# Altium schematic sheet Overview.SchDoc
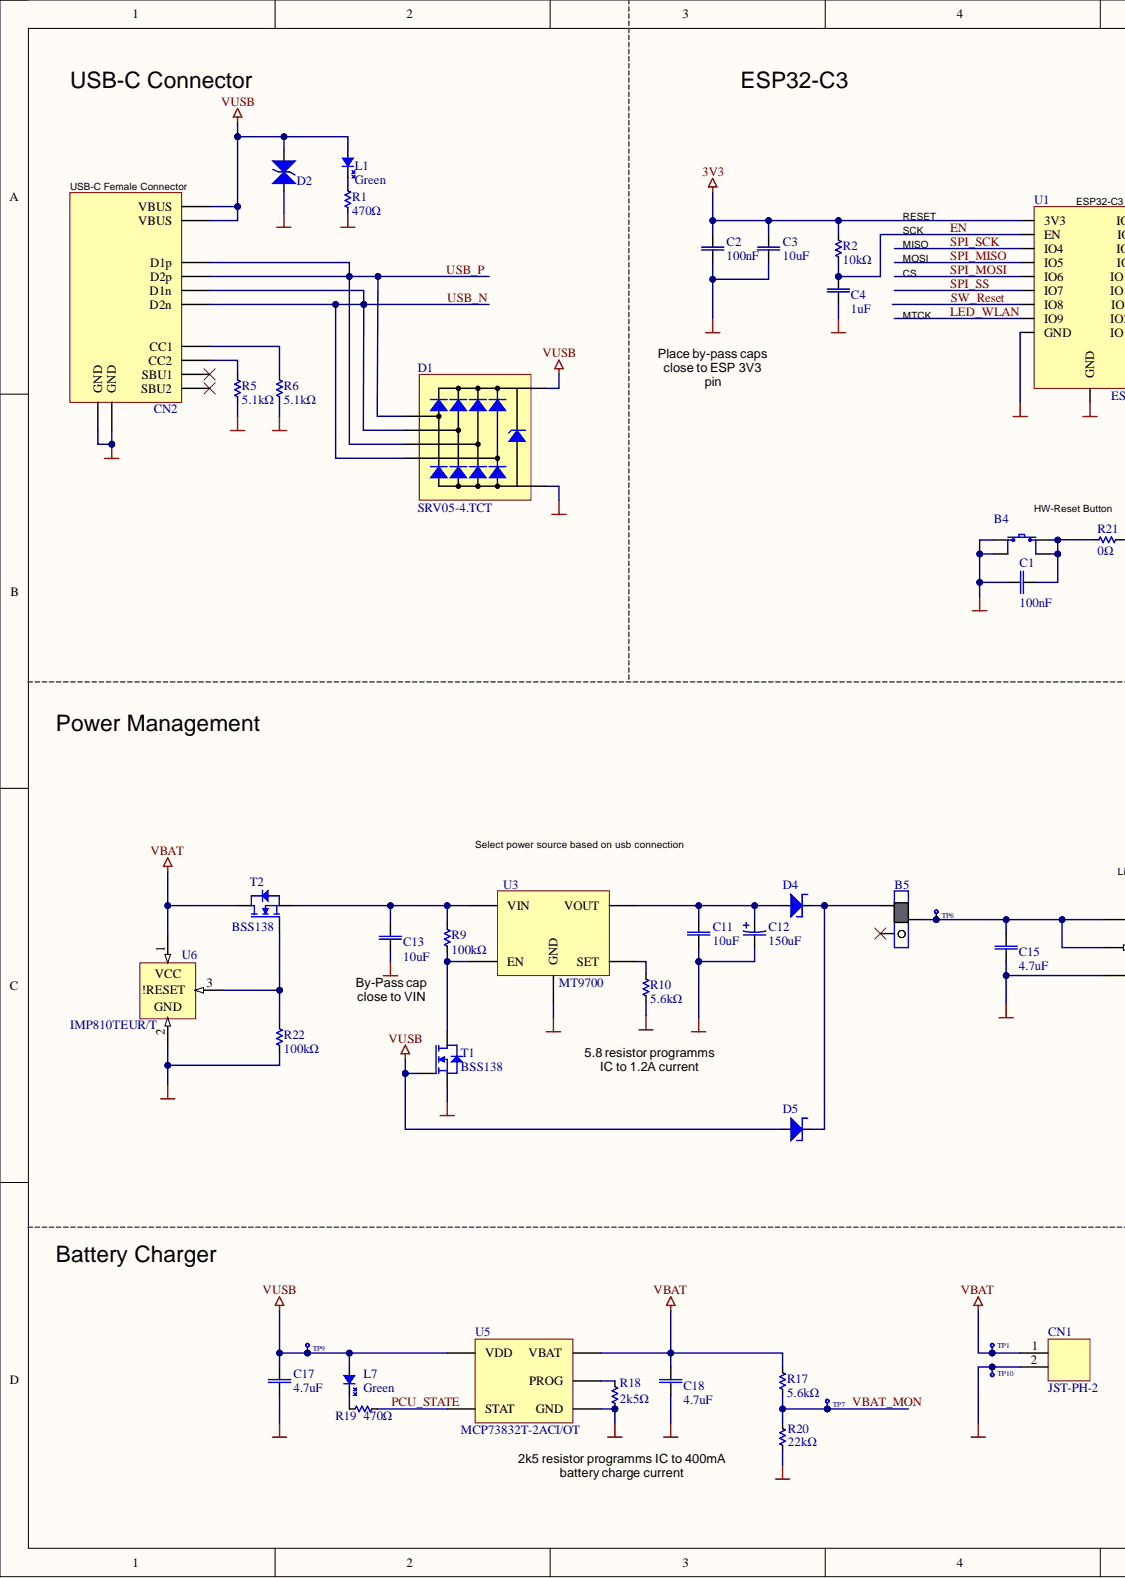
[diagram p0: Overview.SchDoc, left]
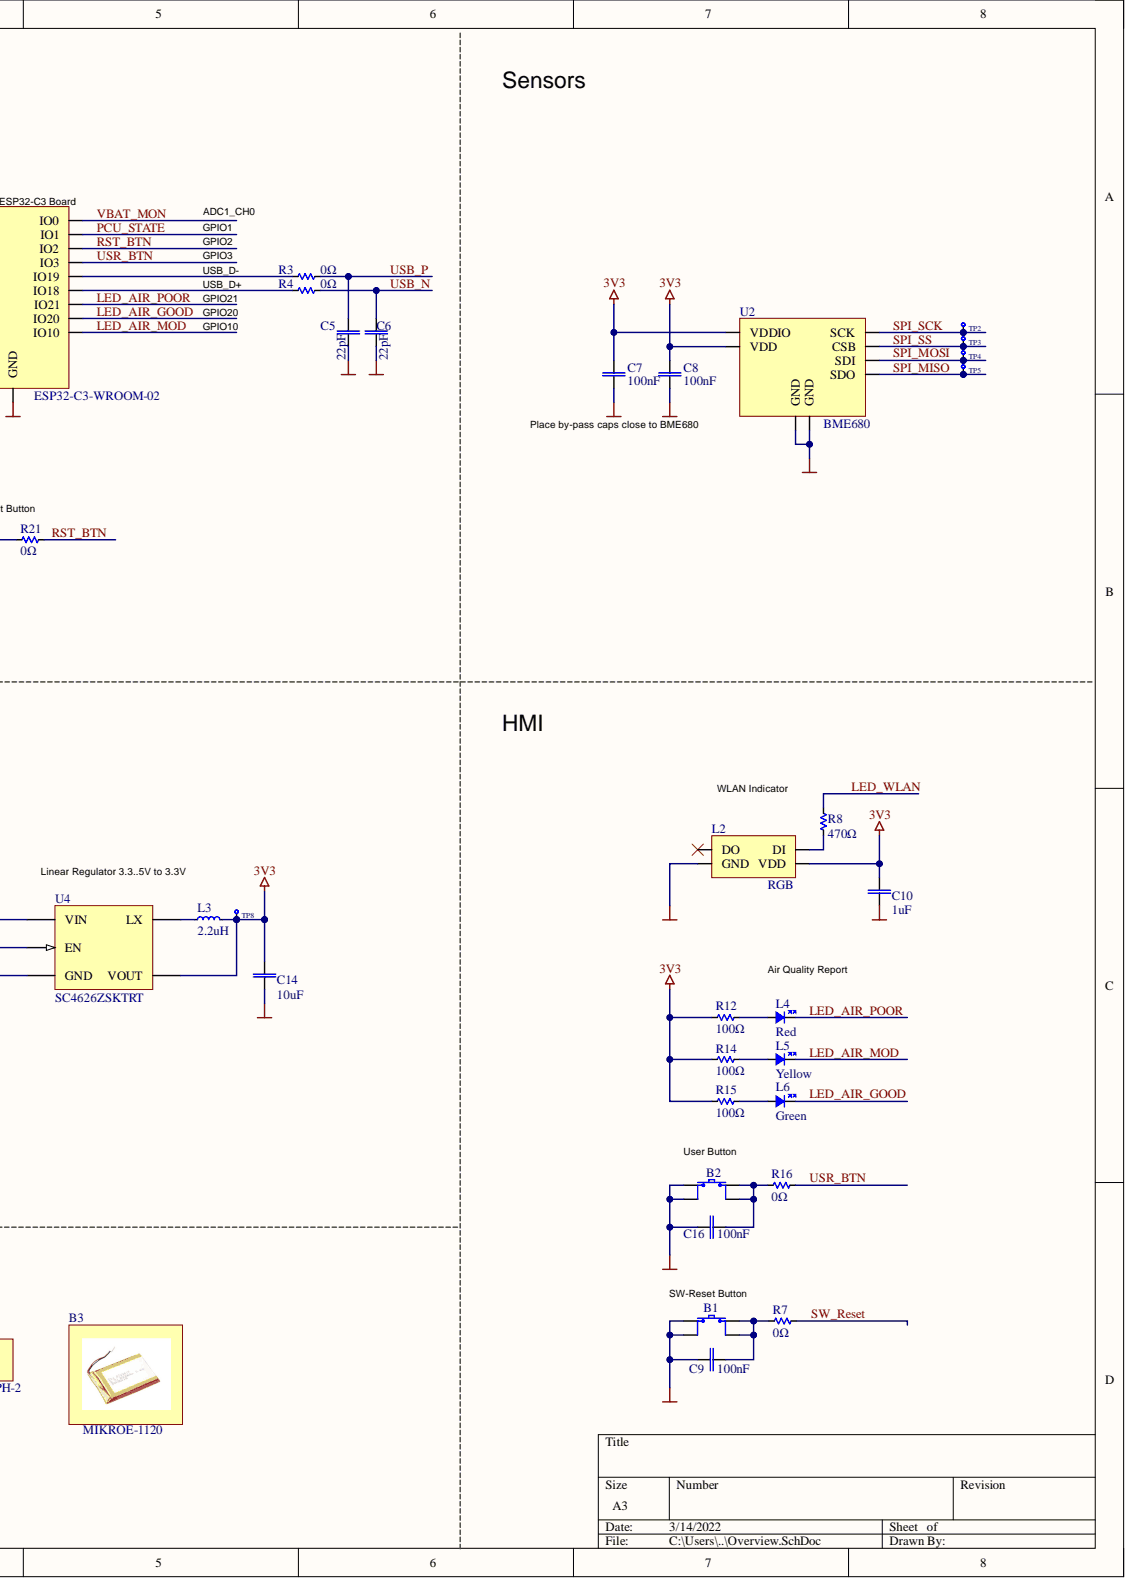
[diagram p1: Overview.SchDoc, right]

## Parts
B1  EVQP7C01P  [K2-1102ST-A4SC-04-Footprint-1]  (value not drawn)
B2  ADTSM644KV  [ADTSM644KV-Footprint-1]  (value not drawn)
B3  MIKROE-1120  [MIKROE-1120-Footprint-1]
B4  KMR221NGLFS  [KSC441G70SHLFS-Footprint-1]  (value not drawn)
B5  SSAL220100  [SSAL220100-Footprint-1]  (value not drawn)
C1  Capacitance: 100nF  [SAMSUNG_CAPC0603]  (hidden comment: CC0603KRX7R9BB104)
C2  Capacitance: 100nF  [SAMSUNG_CAPC0603]  (hidden comment: CC0603KRX7R9BB104)
C3  Capacitance: 10uF  [SAMSUNG_CAPC1206]  (hidden comment: CL31A106KBHNNNE)
C4  Capacitance: 1uF  [SAMSUNG_CAPC0603]  (hidden comment: CL10A105KB8NNNC)
C5  Capacitance: 22pF  [SAMSUNG_CAPC0603]  (hidden comment: CL10C220JB8NNNC)
C6  Capacitance: 22pF  [SAMSUNG_CAPC0603]  (hidden comment: CL10C220JB8NNNC)
C7  Capacitance: 100nF  [SAMSUNG_CAPC0603]  (hidden comment: CC0603KRX7R9BB104)
C8  Capacitance: 100nF  [SAMSUNG_CAPC0603]  (hidden comment: CC0603KRX7R9BB104)
C9  Capacitance: 100nF  [SAMSUNG_CAPC0603]  (hidden comment: CC0603KRX7R9BB104)
C10  Capacitance: 1uF  [SAMSUNG_CAPC0603]  (hidden comment: CL10A105KB8NNNC)
C11  Capacitance: 10uF  [SAMSUNG_CAPC1206]  (hidden comment: CL31A106KBHNNNE)
C12  Capacitance: 150uF  [NICHICON_CAPAE6.3_5.8]  (hidden comment: UUD0J151MCL1GS)
C13  Capacitance: 10uF  [SAMSUNG_CAPC1206]  (hidden comment: CL31A106KBHNNNE)
C14  Capacitance: 10uF  [SAMSUNG_CAPC1206]  (hidden comment: CL31A106KBHNNNE)
C15  Capacitance: 4.7uF  [SAMSUNG_CAPC0603]  (hidden comment: CL10A475KO8NNNC)
C16  Capacitance: 100nF  [SAMSUNG_CAPC0603]  (hidden comment: CC0603KRX7R9BB104)
C17  Capacitance: 4.7uF  [SAMSUNG_CAPC0603]  (hidden comment: CL10A475KO8NNNC)
C18  Capacitance: 4.7uF  [SAMSUNG_CAPC0603]  (hidden comment: CL10A475KO8NNNC)
CN1  JST-PH-2  [1769-Footprint-1]
CN2  216990-0001  [216990-0001-Footprint-1]  (value not drawn)
D1  SRV05-4.TCT  [SEMTECH_SOT23-6L]
D2  LESD5D5.0CT1G  [LRC_SOD-523]  (value not drawn)
D4  B320A-13-F  [DIODES_SMA]  (value not drawn)
D5  B320A-13-F  [DIODES_SMA]  (value not drawn)
L1  Color: Green  [EVERLIGHT_LED0603]  (hidden comment: 19-217/GHC-YR1S2/3T)
L2  Color: RGB  [4492-Footprint-1]  (hidden comment: NeoPixel 1515)
L3  Inductance: 2.2uH  [SUNLORD_INDP3030X15N]  (hidden comment: SWPA3015S2R2MT)
L4  KT-0603R  [EVERLIGHT_LED0603]  (value not drawn)
L5  Color: Yellow  [EVERLIGHT_LED0603]  (hidden comment: 19-213/Y2C-CQ2R2L/3T)
L6  Color: Green  [EVERLIGHT_LED0603]  (hidden comment: 19-217/GHC-YR1S2/3T)
L7  Color: Green  [EVERLIGHT_LED0603]  (hidden comment: 19-217/GHC-YR1S2/3T)
R1  Resistance: 470Ω  [UNIROYAL_RES0603]  (hidden comment: 0603WAF4700T5E)
R2  Resistance: 10kΩ  [UNIROYAL_RES0603]  (hidden comment: 0603WAF1002T5E)
R3  Resistance: 0Ω  [UNYROYAL_RES1206]  (hidden comment: 1206W4F0000T5E)
R4  Resistance: 0Ω  [UNYROYAL_RES1206]  (hidden comment: 1206W4F0000T5E)
R5  Resistance: 5.1kΩ  [UNIROYAL_RES0603]  (hidden comment: 0603WAF5101T5E)
R6  Resistance: 5.1kΩ  [UNIROYAL_RES0603]  (hidden comment: 0603WAF5101T5E)
R7  Resistance: 0Ω  [UNYROYAL_RES1206]  (hidden comment: 1206W4F0000T5E)
R8  Resistance: 470Ω  [UNIROYAL_RES0603]  (hidden comment: 0603WAF4700T5E)
R9  Resistance: 100kΩ  [UNIROYAL_RES0402]  (hidden comment: 0402WGF1003TCE)
R10  Resistance: 5.6kΩ  [UNIROYAL_RES0603]  (hidden comment: 0603WAF5601T5E)
R12  Resistance: 100Ω  [UNIROYAL_RES0603]  (hidden comment: 0603WAF1000T5E)
R14  Resistance: 100Ω  [UNIROYAL_RES0603]  (hidden comment: 0603WAF1000T5E)
R15  Resistance: 100Ω  [UNIROYAL_RES0603]  (hidden comment: 0603WAF1000T5E)
R16  Resistance: 0Ω  [UNYROYAL_RES1206]  (hidden comment: 1206W4F0000T5E)
R17  Resistance: 5.6kΩ  [UNIROYAL_RES0603]  (hidden comment: 0603WAF5601T5E)
R18  Resistance: 2k5Ω  [VIKING_0603RES]  (hidden comment: AR03BTC2501)
R19  Resistance: 470Ω  [UNIROYAL_RES0603]  (hidden comment: 0603WAF4700T5E)
R20  Resistance: 22kΩ  [UNIROYAL_RES0603]  (hidden comment: 0603WAF2202T5E)
R21  Resistance: 0Ω  [UNYROYAL_RES1206]  (hidden comment: 1206W4F0000T5E)
R22  Resistance: 100kΩ  [UNIROYAL_RES0402]  (hidden comment: 0402WGF1003TCE)
T1  BSS138  [ONSEMI_SOT23-3]
T2  BSS138  [ONSEMI_SOT23-3]
TP1  Testpoint  [Testpoint-Footprint-1]  (value not drawn)  (no BOM)
TP2  Testpoint  [Testpoint-Footprint-1]  (value not drawn)  (no BOM)
TP3  Testpoint  [Testpoint-Footprint-1]  (value not drawn)  (no BOM)
TP4  Testpoint  [Testpoint-Footprint-1]  (value not drawn)  (no BOM)
TP5  Testpoint  [Testpoint-Footprint-1]  (value not drawn)  (no BOM)
TP6  Testpoint  [Testpoint-Footprint-1]  (value not drawn)  (no BOM)
TP7  Testpoint  [Testpoint-Footprint-1]  (value not drawn)  (no BOM)
TP8  Testpoint  [Testpoint-Footprint-1]  (value not drawn)  (no BOM)
TP9  Testpoint  [Testpoint-Footprint-1]  (value not drawn)  (no BOM)
TP10  Testpoint  [Testpoint-Footprint-1]  (value not drawn)  (no BOM)
U1  ESP32-C3-WROOM-02  [ESP32-C3-WROOM-02-Footprint-1]
U2  BME680  [BOSCH_8-VFLGA]
U3  MT9700  [AEROSEMI_SOT23-5]
U4  SC4626ZSKTRT  Min Output Voltage: 3.3V  [SOT95P240X145-5N]
U5  MCP73832T-2ACI/OT  [MICOCHIP_SOT23-5]
U6  IMP810TEUR/T  [SOT95P240X110-3N]

## Nets
3V3: C2.2 C3.2 C7.2 C8.2 C10.2 C14.2 L2.4(VDD) L3.2 R2.2 R12.1 R14.1 R15.1 TP8.1 U1.1(3V3) U2.6(VDDIO) U2.8(VDD) U4.4(VOUT)
GND: B1.1 B1.3 B2.1 B2.3 B4.1 B4.3 C1.1 C2.1 C3.1 C4.1 C5.1 C6.1 C7.1 C8.1 C9.1 C10.1 C11.2 C12.2 C13.2 C14.1 C15.1 C16.1 C17.1 C18.1 CN1.2 CN2.1(GND) CN2.12(GND) D1.2 D2.2 L2.2(GND) R1.2 R5.1 R6.1 R10.1 R18.1 R20.1 R22.1 T1.2 TP10.1 U1.9(GND) U1.19(GND) U2.1(GND) U2.7(GND) U3.2(GND) U4.2(GND) U5.2(GND) U6.2
VBAT: C18.2 CN1.1 R17.2 T2.3 TP1.1 U5.3(VBAT) U6.1
VUSB: C17.2 CN2.2(VBUS) CN2.11(VBUS) D1.5 D2.1 D5.2 L1.2 L7.2 T1.1 TP9.1 U5.4(VDD)
EN: C4.2 R2.1 U1.2(EN)
LED_AIR_GOOD: L6.1 U1.11(IO20)
LED_AIR_MOD: L5.1 U1.10(IO10)
LED_AIR_POOR: L4.1 U1.12(IO21)
LED_WLAN: R8.2 U1.8(IO9)
PCU_STATE: R19.1 U1.17(IO1) U5.1(STAT)
RST_BTN: R21.2 U1.16(IO2)
SPI_MISO: TP5.1 U1.4(IO5) U2.5(SDO)
SPI_MOSI: TP4.1 U1.5(IO6) U2.3(SDI)
SPI_SCK: TP2.1 U1.3(IO4) U2.4(SCK)
SPI_SS: TP3.1 U1.6(IO7) U2.2(CSB)
SW_Reset: R7.2 U1.7(IO8)
USB_N: C6.2 CN2.5(D2n) CN2.7(D1n) D1.3 D1.6 R4.2
USB_P: C5.2 CN2.6(D1p) CN2.8(D2p) D1.1 D1.4 R3.2
USR_BTN: R16.2 U1.15(IO3)
VBAT_MON: R17.1 R20.2 TP7.1 U1.18(IO0)
NetB1_2: B1.2 B1.4 C9.2 R7.1
NetB2_2: B2.2 B2.4 C16.2 R16.1
NetB4_2: B4.2 B4.4 C1.2 R21.1
NetB5_1: B5.1 D4.1 D5.1
NetB5_3: B5.3 C15.2 TP6.1 U4.1(VIN) U4.3(EN)
NetC11_1: C11.1 C12.1 D4.2 U3.1(VOUT)
NetC13_1: C13.1 R9.2 T2.2 U3.5(VIN)
NetCN2_4: CN2.4(CC1) R6.2
NetCN2_10: CN2.10(CC2) R5.2
NetL1_1: L1.1 R1.1
NetL2_3: L2.3(DI) R8.1
NetL3_1: L3.1 U4.5(LX)
NetL4_2: L4.2 R12.2
NetL5_2: L5.2 R14.2
NetL6_2: L6.2 R15.2
NetL7_1: L7.1 R19.2
NetR3_1: R3.1 U1.14(IO19)
NetR4_1: R4.1 U1.13(IO18)
NetR9_1: R9.1 T1.3 U3.4(EN)
NetR10_2: R10.2 U3.3(SET)
NetR18_2: R18.2 U5.5(PROG)
NetR22_2: R22.2 T2.1 U6.3

Not connected: B5 2; CN2 3(SBU2) 9(SBU1); L2 1(DO)

## Library data of the parts
B1 = CMP-011-00001-3: SWITCH TACTILE SPST-NO 0.05A 12V; Contact Current Rating: 50mA; Mechanical Life: 100000Cycles; Operating Force: 2.2N; Power Rating: 1W; Throw Configuration: SPST; Voltage Rating (DC): 32V; Component Kind: Standard; Height: 7.3; JLCPCB: C388883; Max Current Rating: 50mA; Max Operating Temperature: 70°C; Max Voltage Rating (DC): 12V; Min Operating Temperature: -20°C; Mount: Surface Mount; Orientation: Straight; REACH SVHC: No SVHC; Library Name: Pedrett
B2 = CMP-011-00003-2: Switch Tactile N.O. SPST Square Button Gull Wing 0.05A 12VDC 0.98N SMD Bulk; Actuator Color: Black; Actuator Length: 3.8mm; Actuator Material: Nylon,Polyamide; Contact Resistance: 100mR; Current Rating: 50mA; Electrical Life: 200000Cycles; Illumination Type: Non-Illuminated; Max Voltage Rating (DC): 12V; Operating Force: 100gf; Switch Function: Off-Mom; Switch Travel: 0.25mm; Case/Package: SMD/SMT; Color: Black; Component Kind: Standard; Contact Material: Bronze,Silver; Contact Plating: Silver; Max Operating Temperature: 70°C; Min Operating Temperature: -25°C; Mount: Surface Mount; Orientation: Straight; Package Quantity: 77; Packaging: Bulk; RoHS Compliant: Yes; Series: ADTS; Termination: Gull Wing; Throw Configuration: SPST; Voltage Rating (DC): 12V; Library Name: Pedrett
B3 = CMP-00044-6: 3.7VWire Lead Term Rechargeable Lithium Battery Pack For Power Supply, 2000mAh; Number of Cells: 1; Operating Supply Voltage: 3.7V; Battery Chemistry: LITHIUM; Capacity: 2000mAh; Chemistry/Technology: Lithium; Component Kind: Standard; Height: 8.5mm; Lead Free: Lead Free; Length: 62.0mm; Output Voltage: 3.7V; Package Quantity: 1; Packaging: Bulk; Rechargeability: Rechargeable; Termination: Wire Leads; Voltage Rating: 3.7V; Weight: 78.5g; Width: 38.5mm; Library Name: Pedrett
B4 = CMP-011-00002-2: Switch Tactile N.O. SPST Button Gull Wing 0.05A 32VDC 1VA 200000Cycles 2N SMD Automotive T/R; Contact Current Rating: 50mA; Max Voltage Rating (DC): 32V; Power Rating: 1W; Ratings: IP67,Waterproof,Dust Tight; Switch Function: Off-Mom; Component Kind: Standard; Height: 5.2mm; JLCPCB: C221747; Max Current Rating: 50mA; Max Operating Temperature: 85°C; Mechanical Life: 200000Cycles; Min Operating Temperature: -40°C; Mount: Surface Mount; Operating Force: 2N; Orientation: Straight; REACH SVHC: No SVHC; Series: KMR2; Throw Configuration: SPST; Voltage Rating (DC): 32V; Library Name: Pedrett
B5 = CMP-00045-5: Switch Slide SPDT Side Slide 0.01A 5VDC 100000Cycles Gull Wing SMD T/R; Contact Current Rating: 10mA; Illumination Type: Non-Illuminated; Max Voltage Rating (DC): 5V; Throw Configuration: SPDT,SP; Case/Package: SMD/SMT; Component Kind: Standard; Max Operating Temperature: 60°C; Min Operating Temperature: -10°C; Mount: Surface Mount; Packaging: Tape and Reel; Radiation Hardening: No; RoHS Compliant: Yes; Series: SSAL; Termination: Gull Wing; Voltage Rating (DC): 5V; Library Name: Pedrett
C1 C2 C7-C9 C16 = CMP-001-00000-5: Cap Ceramic 0.1uF 50V X7R 10% SMD 0603 125C Paper T/R; Case/Package: 0603; Component Kind: Standard; Depth: 0.8mm; Dielectric: X7R; JLCPCB: C14663; Length: 1.6mm; Max Operating Temperature: 125°C; Min Operating Temperature: -55°C; Mount: Surface Mount; RoHS Compliant: Yes; Tolerance: 10%; Voltage: 50V; Library Name: Pedrett
C3 C11 C13 C14 = CMP-001-00003-2: SAMSUNG ELECTRO-MECHANICS - CL31A106KBHNNNE - SMD Multilayer Ceramic Capacitor, 10 µF, 50 V, 1206 [3216 Metric], ± 10%, X5R, CL Series; Voltage: 50V; Case/Package: 1206; Component Kind: Standard; Depth: 1.6mm; Dielectric: X5R; JLCPCB: C13585; Length: 3.2mm; Max Operating Temperature: 85°C; Min Operating Temperature: -55°C; Mount: Surface Mount; RoHS Compliant: Yes; Tolerance: 10%; Library Name: Pedrett
C4 C10 = CMP-001-00004-1: Cap Ceramic 1uF 50V X5R 10% SMD 0603 85C Cardboard T/R; Case/Package: 0603; Component Kind: Standard; Dielectric: X5R; Height: 0.8mm; JLCPCB: C15849; Length: 1.6mm; Material: Ceramic; Max Operating Temperature: 85°C; Min Operating Temperature: -55°C; Mount: Surface Mount; RoHS Compliant: Yes; Tolerance: 10%; Voltage: 50V; Library Name: Pedrett
C5 C6 = CMP-001-00012-1: Ceramic capacitor SMD-0603 22pF /50V NP0# J +-5%, Samsung, RoHS; Dielectric: C0G; Tolerance: 5%; Voltage: 50V; Case/Package: 0603; Component Kind: Standard; Height: 0.8mm; JLCPCB: C1653; Length: 0.063inch; Material: Ceramic; Max Operating Temperature: 125°C; Min Operating Temperature: -55°C; Mount: Surface Mount; RoHS Compliant: Yes; Library Name: Pedrett
C12 = CMP-001-00010-1: Cap Aluminum Lytic 150uF 6.3V 20% (6.3 X 5.8mm) SMD 230mA 2000h 105C Automotive T/R; Dissipation Factor: 0.26%; Impedance: 440mR; Leakage Current: 3uA; Life (Hours): 2000Hour; Case/Package: Radial; Component Kind: Standard; Construction: Cylindrical; Diameter: 6.3mm; Height: 5.8mm; Height - Seated (Max): 0.228inch; JLCPCB: C445282; Lead Free: Lead Free; Length: 5.8mm; Max Operating Temperature: 105°C; Min Operating Temperature: -55°C; Number of Pins: 2; Package Quantity: 1; Packaging: Tape and Reel; Radiation Hardening: No; Ripple Current: 230mA; RoHS Compliant: Yes; Series: UD; Termination: SMD/SMT; Tolerance: 20%; Voltage Rating: 6.3V; Voltage Rating (DC): 6.3V; Library Name: Pedrett
C15 C17 C18 = CMP-001-00006-1: Ceramic capacitor SMD 0603 4.7 µF 16 V 10 %; Dielectric: X5R; Tolerance: 10%; Voltage: 16V; Case/Package: 0603; Component Kind: Standard; Height: 0.8mm; JLCPCB: C19666; Length: 1.6mm; Material: Ceramic; Max Operating Temperature: 85°C; Min Operating Temperature: -55°C; Mount: Surface Mount; RoHS Compliant: Yes; Library Name: Pedrett
CN1 = CMP-002-00010-2: CML 1769 LMP T-1 3/4 INC Midget Screw .2A 2.5V Part of the VCC Family of Products; Connector Type: Male Pin; Contact Resistance: 10mR; Current Rating: 2A; Max Voltage Rating (AC): 100V; Number of Contacts: 2; Pitch: 2.5mm; Color: Natural; Component Kind: Standard; Contact Material: Brass; Contact Plating: Tin; Contact Type: Male Pin; Lead Free: Lead Free; Lead Pitch: 2.5mm; Length: 7.4mm; Max Current Rating: 2A; Max Operating Temperature: 85°C; Max Voltage Rating (DC): 100V; Min Operating Temperature: -25°C; Mount: SMD; Number of Positions: 2; Number of Rows: 1; Orientation: Right Arrow; REACH SVHC: No SVHC; Library Name: Pedrett
CN2 = CMP-002-00009-4: USB Type - Connector, Mid-mount, SMT, CH-0.52, 16pos; Current Max (Other): 1.25A; Current Max (VBus): 30V; Durability: 10000 Cycles; Component Kind: Standard; Max Operating Temperature: 85°C; Min Operating Temperature: -40°C; Pin: 16; Voltage AC: 30V; Library Name: Pedrett
D1 = CMP-00030-3: SEMTECH - SRV05-4.TCT - ESD Protection Device, TVS, 17.5 V, SOT-23, 6 Pins, 5 V, 300 W; Breakdown Voltage: 6V; Capacitance: 5pF; Clamping Voltage: 17.5V; Current: 5A; ESD Protection: Yes; Max Power Dissipation: 300W; Max Reverse Leakage Current: 5uA; Number of Bidirectional Channels: 4; Operating Supply Voltage: 5V; Peak Pulse Current: 12A; Polarity: Unidirectional; Case/Package: SOT-23; Component Kind: Standard; JLCPCB: C13612; Lead Free: Lead Free; Max Breakdown Voltage: 6V; Max Operating Temperature: 125°C; Min Breakdown Voltage: 6V; Min Operating Temperature: -55°C; Mount: Surface Mount; Peak Pulse Power: 300W; REACH SVHC: No SVHC; Reverse Breakdown Voltage: 6V; Reverse Standoff Voltage: 5V; RoHS Compliant: Yes; Series: RailClamp®, SR; Library Name: Pedrett
D2 = CMP-00043-2: 5V 5.6V Bidirectional 18.6V 9.4A (8/20us) SOD-523 ESD Protection Devices ROHS; Clamping Voltage: 11.6; Peak Pulse Power: 150W; Breakdown Voltage: 5.6V; Case/Package: SOD-523; Component Kind: Standard; JLCPCB: C383211; RoHS Compliant: Yes; Voltage: 5V; Working Peak Reverse Voltage: 5V; Library Name: Pedrett
D4 D5 = CMP-004-00001-1: Rectifier Diode Schottky 20V 3A 2-Pin SMA T/R / DIODE SCHOTTKY 20V 3A SMA; Capacitance: 250pF; Element Configuration: Single; Forward Voltage: 500mV; Max Forward Surge Current (Ifsm): 80A; Max Reverse Current: 500uA; Polarity: Standard; Average Rectified Current: 3A; Case/Package: SMA; Component Kind: Standard; Current Rating: 3A; Forward Current: 3A; Height: 2.3mm; JLCPCB: C110484; Lead Free: Lead Free; Length: 4.6mm; Max Operating Temperature: 150°C; Max Repetitive Reverse Voltage (Vrrm): 20V; Max Reverse Voltage (DC): 20V; Min Operating Temperature: -55°C; Mount: Surface Mount; Number of Pins: 2; Output Current: 3A; Package Quantity: 5000; Packaging: Tape and Reel; Peak Non-Repetitive Surge Current: 80A; Radiation Hardening: No; REACH SVHC: No SVHC; RoHS Compliant: Yes; Series: -; Termination: SMD/SMT; Voltage Rating (DC): 20V; Weight: 0.002258oz; Width: 2.92mm; Library Name: Pedrett
L1 L6 L7 = CMP-00009-9: LED Uni-Color Green 518nm 2-Pin Chip LED T/R; Dominant Wavelength: 528nm; Forward Current: 20mA; Forward Voltage: 3.3V; Lens Color: Colorless; Lens Style: Clear,Diffused,Colorless,Rectangular; Lens Transparency: Clear; Luminous Intensity: 199mcd; Viewing Angle: 120°; Case/Package: 0603; Component Kind: Standard; Height: 0.45mm; JLCPCB: C72043; Lead Free: Lead Free; Length: 1.6mm; Mount: Surface Mount; Radiation Hardening: No; RoHS Compliant: Yes; Library Name: Pedrett
L2 = CMP-001-0010-1: NeoPixel Addressable 1515 LEDs (1.5mm x 1.5mm) - 10 pack; Dominant Wavelength: 465nm; Forward Voltage: 3.7 to 5.5V; Lens Color: Colorless; Lens Transparency: Clear; Luminous Intensity: 30mcd; Test Current: 5mA; Viewing Angle: 120°; Component Kind: Standard; Height: 2.1mm; Length: 1.5mm; Mount: Surface Mount; Packaging: Tape and Reel; RoHS Compliant: Yes; Width: 1.5mm; Library Name: Pedrett
L3 = CMP-005-00001-1: 2.2uH ±10% 2.45A 60mΩ SMD,3.0x3.0x1.5mm Power Inductors RoHS; Component Kind: Standard; DC Resistance: 60mΩ; JLCPCB: C43389; Rated Current: 2.45A; RoHS: Yes; Tolerance: ±10%; Library Name: Pedrett
L4 = CMP-001-0005-1: LED Uni-Color Red 630nm 2-Pin Chip LED T/R; Forward Current: 20mA; Forward Voltage: 1.9; Lens Color: Colorless; Lens Style: Clear,Diffused,Colorless,Rectangular; Lens Transparency: Clear; Luminous Intensity: 199mcd; Viewing Angle: 70°; Case/Package: 0603; Color: Red; Component Kind: Standard; Dominant Wavelength: 630nm; Height: 0.45mm; JLCPCB: C2286; Lead Free: Lead Free; Length: 1.6mm; Mount: Surface Mount; Radiation Hardening: No; RoHS Compliant: Yes; Library Name: Pedrett
L5 = CMP-001-0000-9: SMD LED yellow 1.7. . .2.3 V 0603, 19-213/Y2C-CQ2R2L/3T, Everlight Electronics; Forward Current: 20mA; Forward Voltage: 2.3V; Lens Color: Clear; Lens Style: Diffused,Colorless,Rectangular; Luminous Intensity: 180mcd; Power Rating: 60mW; Viewing Angle: 120°; Case/Package: 0603; Component Kind: Standard; Illumination Color: Yellow; JLCPCB: C72038; Max Operating Temperature: 85°C; Min Operating Temperature: -40°C; Library Name: Pedrett
R1 R8 R19 = CMP-009-00024-4: 470Ω ±1% 0.1W ±100ppm/℃ 0603 Chip Resistor - Surface Mount RoHS; Montage: SMD; Voltage: 50 V; Case/Package: 0603; Component Kind: Standard; JLCPCB: C23179; Power: 0.1W; RoHS: Yes; RoHS Compliant: Yes; Temperature Coefficient: ±100ppm/℃; Tolerance: ±1%; Library Name: Pedrett
R2 = CMP-009-00003-3: 10kΩ ±1% 0.1W ±100ppm/℃ 0603 Chip Resistor - Surface Mount RoHS; Montage: SMD; Voltage: 50 V; Case/Package: 0603; Component Kind: Standard; JLCPCB: C25804; Power: 0.1W; RoHS: Yes; RoHS Compliant: Yes; Temperature Coefficient: ±100ppm/℃; Tolerance: ±1%; Library Name: Pedrett
R3 R4 R7 R16 R21 = CMP-009-00020-3: CHIP RESISTOR - SURFACE MOUNT 0OHMS ±1% 1/4W 1206 ROHS; Montage: SMD; Power: 0.25W; Temperature Coefficient: 200ppm/°C; Voltage: 50 V; Case/Package: 1206; Component Kind: Standard; JLCPCB: C17888; RoHS: Yes; RoHS Compliant: Yes; Tolerance: ±1%; Library Name: Pedrett
R5 R6 = CMP-009-00026-3: 5.1kΩ ±1% 0.1W ±100ppm/℃ 0603 Chip Resistor - Surface Mount RoHS; Montage: SMD; Power: 0.125W; Voltage: 50 V; Case/Package: 0603; Component Kind: Standard; JLCPCB: C23186; RoHS: Yes; RoHS Compliant: Yes; Temperature Coefficient: ±100ppm/℃; Tolerance: ±1%; Library Name: Pedrett
R9 R22 = CMP-009-00005-4: 100kΩ ±1% 0.0625W ±100ppm/℃ 0402 Chip Resistor - Surface Mount RoHS; Montage: SMD; Voltage: 50 V; Case/Package: 0402; Component Kind: Standard; JLCPCB: C25741; Power: 0.0625W; RoHS: Yes; RoHS Compliant: Yes; Temperature Coefficient: ±100ppm/℃; Tolerance: ±1%; Library Name: Pedrett
R10 R17 = CMP-009-00010-2: 5.6kΩ ±1% 0.1W ±100ppm/℃ 0603 Chip Resistor - Surface Mount RoHS; Montage: SMD; Power: 0.125W; Voltage: 50 V; Case/Package: 0603; Component Kind: Standard; JLCPCB: C23189; RoHS: Yes; RoHS Compliant: Yes; Temperature Coefficient: ±100ppm/℃; Tolerance: ±1%; Library Name: Pedrett
R12 R14 R15 = CMP-009-00008-4: 100Ω ±1% 0.1W ±200ppm/℃ 0603 Chip Resistor - Surface Mount RoHS; Montage: SMD; Voltage: 50 V; Case/Package: 0603; Component Kind: Standard; JLCPCB: C22775; Power: 0.1W; RoHS: Yes; RoHS Compliant: Yes; Temperature Coefficient: ±200ppm/℃; Tolerance: ±1%; Library Name: Pedrett
R18 = CMP-009-00028-1: ±0.1% 1/16W ±25ppm/℃ 2.5kΩ 0603 Chip Resistor - Surface Mount ROHS; Montage: SMD; Temperature Coefficient: ±5ppm/℃; Voltage: 50 V; Case/Package: 0603; Component Kind: Standard; JLCPCB: C304065; Power: 1/16W; RoHS: Yes; RoHS Compliant: Yes; Tolerance: ±0.1%; Library Name: Pedrett
R20 = CMP-009-00031-2: 22kΩ ±1% 0.1W ±200ppm/℃ 0603 Chip Resistor - Surface Mount RoHS; Montage: SMD; Voltage: 50 V; Case/Package: 0603; Component Kind: Standard; JLCPCB: C31850; Power: 0.1W; RoHS: Yes; RoHS Compliant: Yes; Temperature Coefficient: ±200ppm/℃; Tolerance: ±1%; Library Name: Pedrett
T1 T2 = CMP-000001-7: Trans MOSFET N-CH 50V 0.22A 3-Pin SOT-23 T/R; Rds On: 3.5Ω; Type: n-Channel; Vgs Max: 1.5V; Case/Package: SOT-23-3; Component Kind: Standard; JLCPCB: C52895; V dss: 50V; Library Name: Pedrett
TP1-TP10 = CMP-00019-8: A simple testpoint; Library Name: Pedrett
U1 = CMP-001-0004-6: 2.4 GHz WiFi, (802.11 b/g/n) and Bluetooth 5 module, Built around ESP32-C3, RISCV, singlecore, 4 MB flash, 15 GPIOs, Onboard; Component Kind: Standard; Library Name: Pedrett
U2 = CMP-001-0009-2: Pressure, Temperature and Humidity Sensor Digital Output 1.8V 8-Pin LGA; Accuracy: 3%; Manfacturer-No: 828-1063-1-ND; Output Type: SPI,I2C; Response Time: 1s; Case/Package: LGA; Component Kind: Standard; Lead Free: Lead Free; Max Operating Temperature: 85°C; Min Operating Temperature: -40°C; Mount: Surface Mount; Number of Pins: 8; Packaging: Cut Tape; Sensor Type: Temperature; Library Name: Pedrett
U3 = CMP-00041-3: SOT-23-5 Power Distribution Switches ROHS; Input Voltage Max: 5.5V; Input Voltage Min: 2.4V; Power Dissipation: 0.4W; Component Kind: Standard; JLCPCB: C89855; Library Name: Pedrett
U4 = CMP-001-0007-5: 2.5MHz 1.0A Synchronous Step Down Regulator in SOT23-5; Efficiency: 93%; Max Input Voltage: 5.5V; Min Input Voltage: 2.9V; Number of Outputs: 1; Output Configuration: Positive; Output Current: 1A; Output Type: Fixed; Synchronous Rectifier: Yes; Case/Package: SOT-23-5; Component Kind: Standard; Datasheet: https://www.mouser.ch/datasheet/2/761/SEMT_S_A0000087366_1-2575276.pdf; Lead Free: Lead Free; Max Operating Temperature: 125°C; Min Operating Temperature: -40°C; Mount: Surface Mount; Number of Pins: 5; Output Voltage: 3.3V; Packaging: Tape and Reel; RoHS Compliant: Yes; Switching Frequency: 2.5MHz; Library Name: Pedrett
U5 = CMP-00040-2: MCP73832 Series Single-Cell Li-Ion/Li-Polymer Battery Charge Controller SOT-23-5; Max Charge Current: 500mA; Max Input Voltage: 6V; Max Output Voltage: 4.5V; Max Power Dissipation: 1.54W; Min Output Voltage: 4.168V; Min Supply Voltage: 3.75V; Number of Cells: 1; Output Current: 10mA; Output Voltage: 4.2V; Case/Package: SOT-23; Component Kind: Standard; Height: 1.3mm; Lead Free: Lead Free; Length: 3.1mm; Max Operating Temperature: 85°C; Max Output Current: 500mA; Max Supply Voltage: 6V; Min Operating Temperature: -40°C; Mount: Surface Mount; Number of Channels: 1; Number of Outputs: 1; Number of Pins: 5; Package Quantity: 3000; Packaging: Tape and Reel; Power Dissipation: 1.54W; Radiation Hardening: No; REACH SVHC: No SVHC; RoHS Compliant: Yes; Termination: SMD/SMT; Width: 1.8mm; Library Name: Pedrett
U6 = CMP-001-0008-3: 3.08V Power Supply Supervisor; Case/Package: SOT-23; Component Kind: Standard; JLCPCB: C7899; Library Name: Pedrett
